# Altium schematic sheet B.ELI_CELL_L.SchDoc
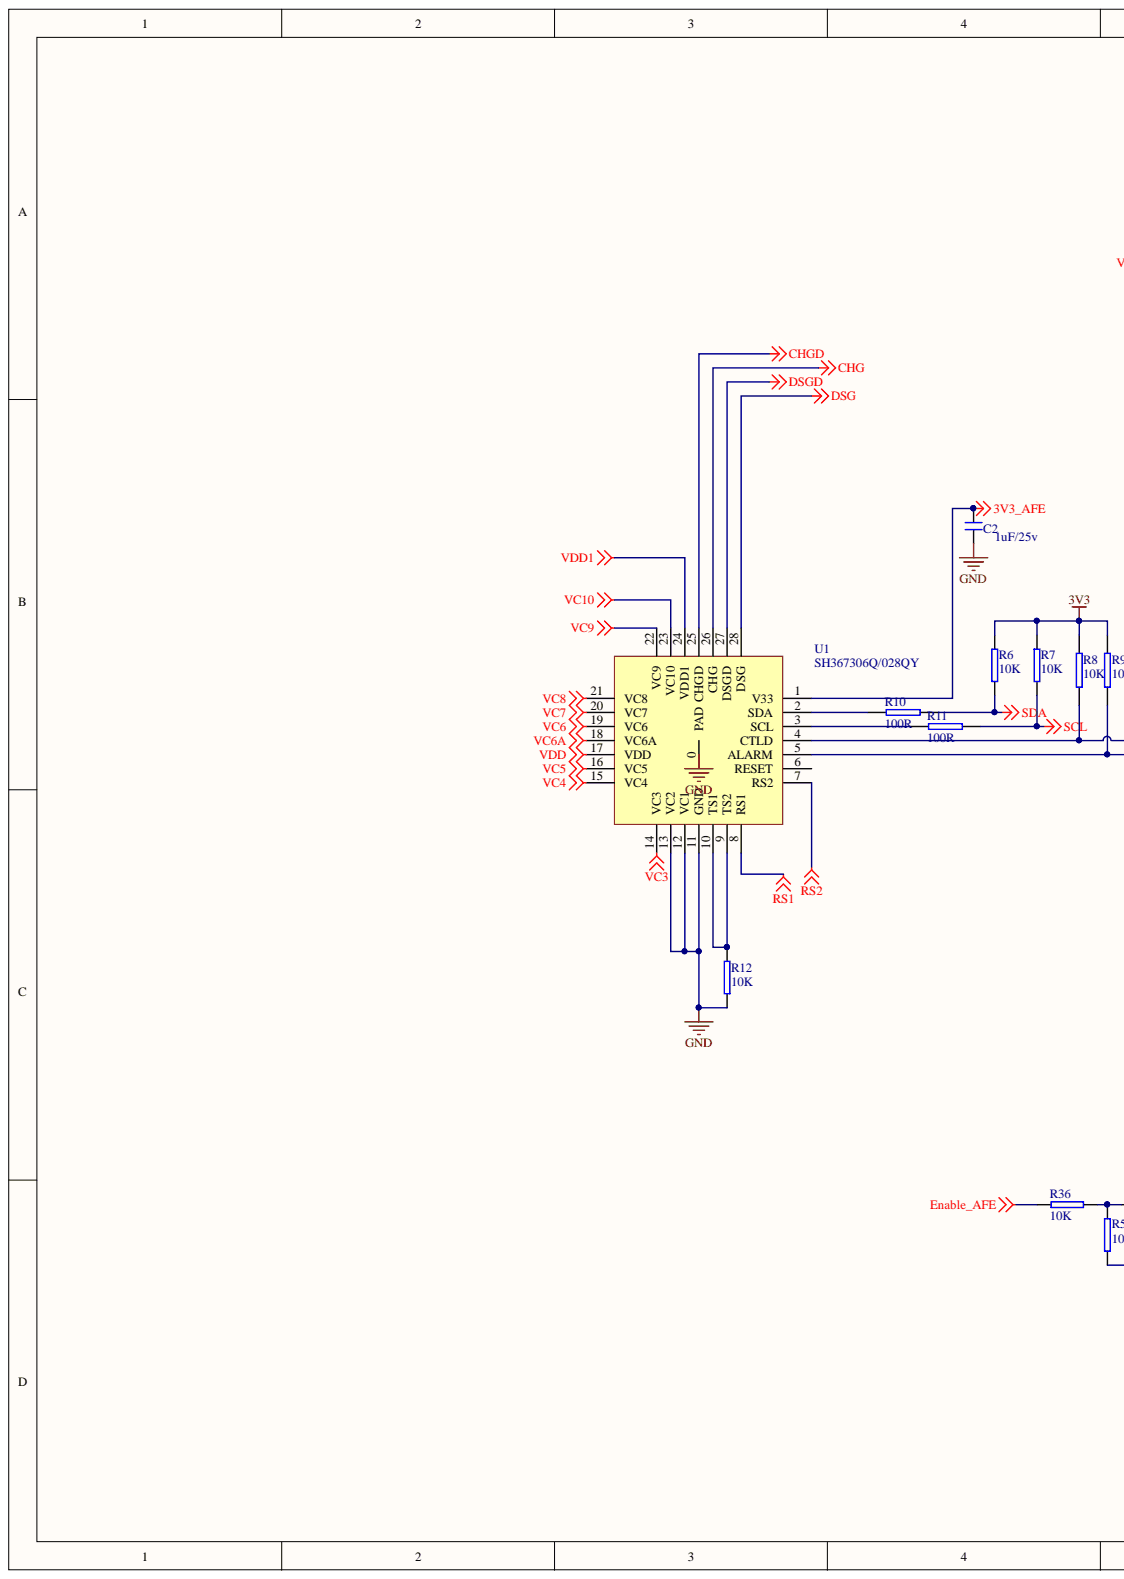
[diagram p0: B.ELI_CELL_L.SchDoc, left]
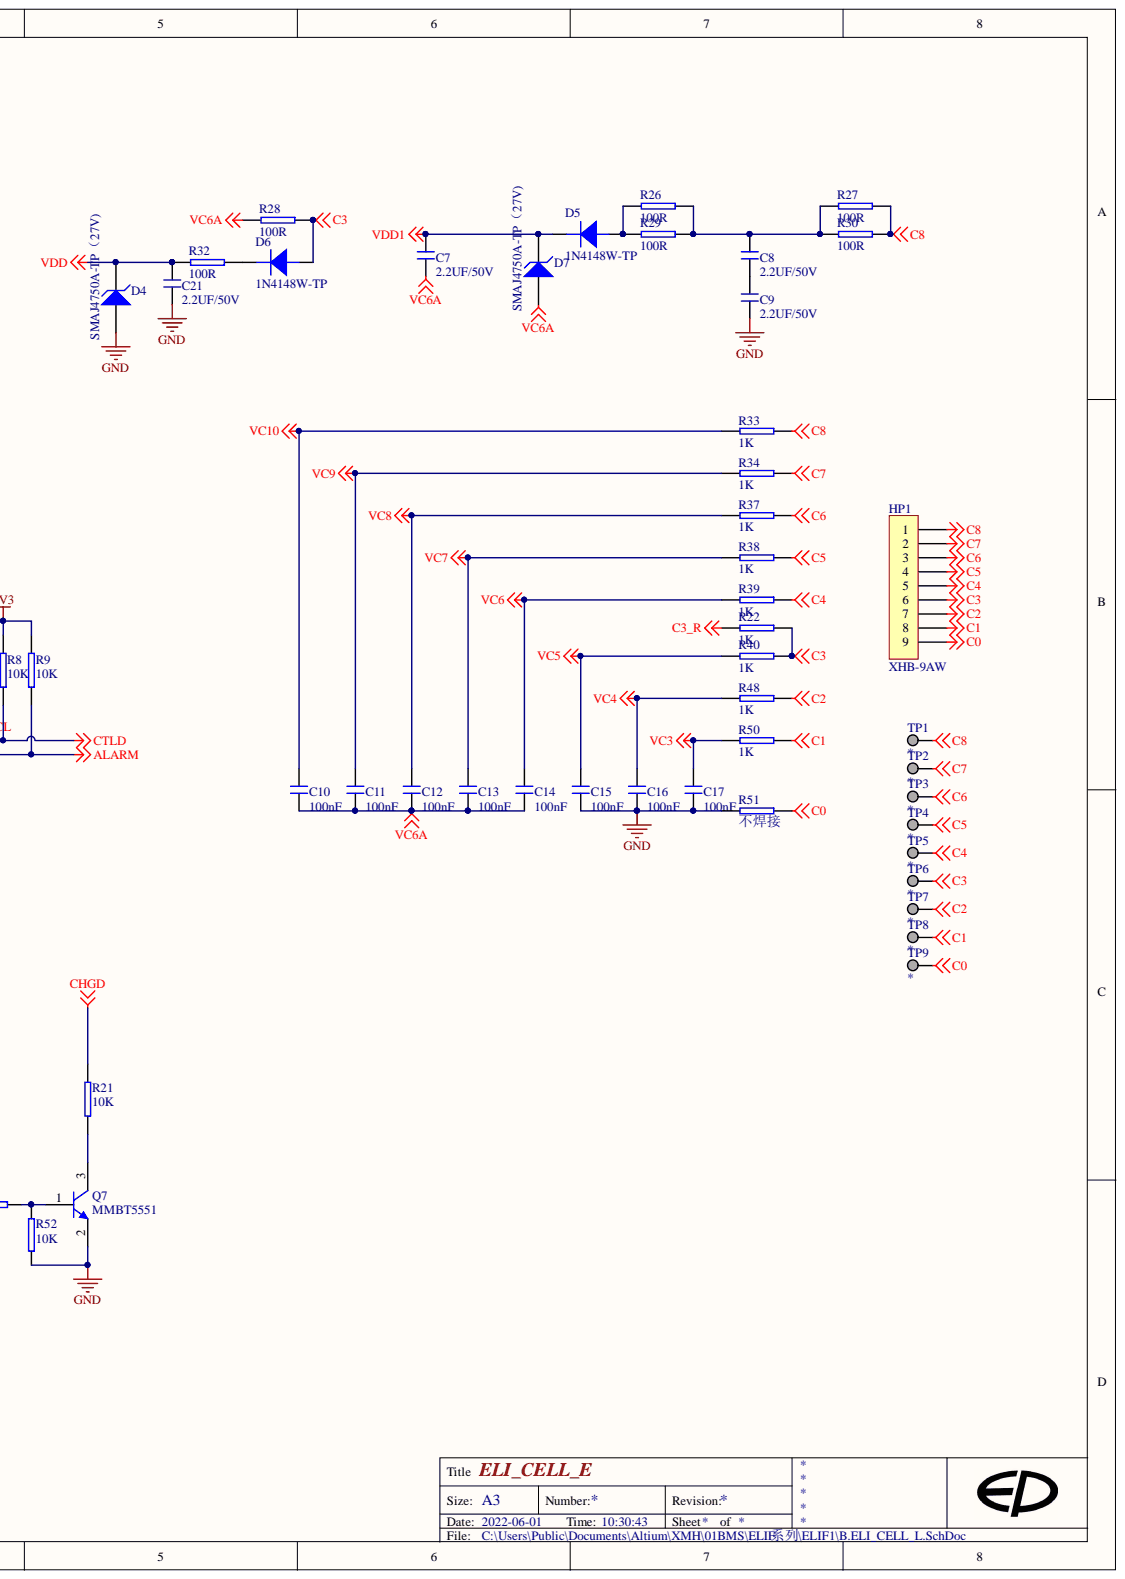
[diagram p1: B.ELI_CELL_L.SchDoc, right]

## Parts
C2  1uF/25v  [0603-C]
C7  2.2UF/50V  [0805-C]
C8  2.2UF/50V  [0805-C]
C9  2.2UF/50V  [0805-C]
C10  100nF  [0603-C]
C11  100nF  [0603-C]
C12  100nF  [0603-C]
C13  100nF  [0603-C]
C14  100nF  [0603-C]
C15  100nF  [0603-C]
C16  100nF  [0603-C]
C17  100nF  [0603-C]
C21  2.2UF/50V  [0805-C]
D4  SMAJ4750A-TP（27V)  [SMA_C]
D5  1N4148W-TP  [SOD-123]
D6  1N4148W-TP  [SOD-123]
D7  SMAJ4750A-TP（27V)  [SMA_C]
HP1  XHB-9AW  [XH2.54-9P-C]
Q7  MMBT5551  [SOT-23M]
R6  10K  [0603-R]
R7  10K  [0603-R]
R8  10K  [0603-R]
R9  10K  [0603-R]
R10  100R  [0603-R]
R11  100R  [0603-R]
R12  10K  [0603-R]
R21  10K  [0603-R]
R22  1K  [0603-R]
R26  100R  [0805-R]
R27  100R  [0805-R]
R28  100R  [0805-R]
R29  100R  [0805-R]
R30  100R  [0805-R]
R32  100R  [0805-R]
R33  1K  [0603-R]
R34  1K  [0603-R]
R36  10K  [0603-R]
R37  1K  [0603-R]
R38  1K  [0603-R]
R39  1K  [0603-R]
R40  1K  [0603-R]
R48  1K  [0603-R]
R50  1K  [0603-R]
R51  不焊接  [0603-R]
R52  10K  [0603-R]
TP1  (no value)  [TESTPOINT50]
TP2  (no value)  [TESTPOINT50]
TP3  (no value)  [TESTPOINT50]
TP4  (no value)  [TESTPOINT50]
TP5  (no value)  [TESTPOINT50]
TP6  (no value)  [TESTPOINT50]
TP7  (no value)  [TESTPOINT50]
TP8  (no value)  [TESTPOINT50]
TP9  (no value)  [TESTPOINT50]
U1  SH367306Q/028QY  [QFN-28_5x5x05L]

## Nets
3V3: R6.2 R7.2 R8.1 R9.1
3V3_AFE: C2.1 U1.1(V33)
ALARM: R9.2 U1.5(ALARM)
C0: HP1.9 R51.2 TP9.1
C1: HP1.8 R50.2 TP8.1
C2: HP1.7 R48.2 TP7.1
C3: D6.2 HP1.6 R22.2 R28.2 R40.2 TP6.1
C3_R: R22.1
C4: HP1.5 R39.2 TP5.1
C5: HP1.4 R38.2 TP4.1
C6: HP1.3 R37.2 TP3.1
C7: HP1.2 R34.2 TP2.1
C8: HP1.1 R27.2 R30.2 R33.2 TP1.1
CHG: U1.26(CHG)
CHGD: R21.1 U1.25(CHGD)
CTLD: R8.2 U1.4(CTLD)
DSG: U1.28(DSG)
DSGD: U1.27(DSGD)
Enable_AFE: R36.2
GND: C2.2 C9.1 C15.1 C16.1 C17.1 C21.1 D4.2 Q7.2 R12.2 R51.1 R52.2 U1.0(PAD) U1.11(GND) U1.12(VC1) U1.13(VC2)
RS1: U1.8(RS1)
RS2: U1.7(RS2)
SCL: R7.1 R11.2
SDA: R6.1 R10.2
VC3: C17.2 R50.1 U1.14(VC3)
VC4: C16.2 R48.1 U1.15(VC4)
VC5: C15.2 R40.1 U1.16(VC5)
VC6: C14.2 R39.1 U1.19(VC6)
VC6A: C7.1 C10.1 C11.1 C12.1 C13.1 C14.1 D7.2 R28.1 U1.18(VC6A)
VC7: C13.2 R38.1 U1.20(VC7)
VC8: C12.2 R37.1 U1.21(VC8)
VC9: C11.2 R34.1 U1.22(VC9)
VC10: C10.2 R33.1 U1.23(VC10)
VDD: C21.2 D4.1 R32.1 U1.17(VDD)
VDD1: C7.2 D5.1 D7.1 U1.24(VDD1)
NetC8_1: C8.1 C9.2
NetC8_2: C8.2 R26.2 R27.1 R29.2 R30.1
NetD5_2: D5.2 R26.1 R29.1
NetD6_1: D6.1 R32.2
NetQ7_1: Q7.1 R36.1 R52.1
NetQ7_3: Q7.3 R21.2
NetR10_1: R10.1 U1.2(SDA)
NetR11_1: R11.1 U1.3(SCL)
NetR12_1: R12.1 U1.9(TS2) U1.10(TS1)

Not connected: U1 6(RESET)

## Library data of the parts
C2 = Cap: Component Kind: Standard; Value: 1uF/25v; Library Name: xmh_c.SchLib
C7-C17 C21 = Cap: 贴片电容; Component Kind: Standard; Library Name: xmh_c.IntLib
  C7-C9 C21: Value: 2.2UF/50V
  C10-C17: Value: 100nF
D4 D7 = DIODE_W: 稳压二极管; Parameter 1: MMSZ5243BT1G; Parameter 2: MMSZ5248BT1G; Parameter 3: MMSZ5258BT1G; Parameter 4: MMSZ5266BT1G; Parameter 5: MMSZ5267BT1G; Parameter 6: MM3Z5V6T1G; Component Kind: Standard; Value: SMAJ4750A-TP（27V); Library Name: xmh_c.IntLib
D5 D6 = DIODE: 贴片二极管; Parameter 2: SS110-LTP; Parameter 3: SL110PL; Parameter 4: B5819WS; Parameter 5: ES2G-E3/52T; Parameter 6: 1N4148WT-7; Parameter 7: 1N4148WS; Parameter 8: BAV21WS; Component Kind: Standard; Parameter 1: 1N4148W-TP; Value: 1N4148W-TP; Library Name: xmh_c.IntLib
HP1 = XH-9P: Component Kind: Standard; Library Name: xmh_c.IntLib
Q7 = NPN: 贴片三极管; Parameter 1: ZXTN4004KTC; Component Kind: Standard; Value: MMBT5551; Library Name: xmh_c.SchLib
R6-R11 R21 = RES T: 电阻; Component Kind: Standard
  R6-R9 R21: Value: 10K
  R10 R11: Value: 100R
  R6 R7: Library Name: xmh_c.SchLib
  R8-R11 R21: Library Name: xmh_c.IntLib
R12 R22 R26-R30 R32-R34 R36-R40 R48 R50-R52 = RES T: 贴片电阻; Component Kind: Standard
  R22 R33 R34 R37-R40 R48 R50: Value: 1K
  R26-R30 R32: Value: 100R
  R51: Value: 不焊接
  R12 R36 R52: Library Name: xmh_c.SchLib
  R22 R26-R30 R32-R34 R37-R40 R48 R50 R51: Library Name: xmh_c.IntLib
TP1-TP9 = TESTPOINT: 测试点; Component Kind: Standard; Library Name: xmh_c.IntLib
U1 = SH36730X: AFE; Parameter 2: SH367305Q/028QY; Component Kind: Standard; Parameter 3: SH367306Q/028QY; Value: SH367306Q/028QY; Library Name: xmh_c.IntLib
